# Altium schematic sheet LM2576-v2.SchDoc(LM2576-v2)
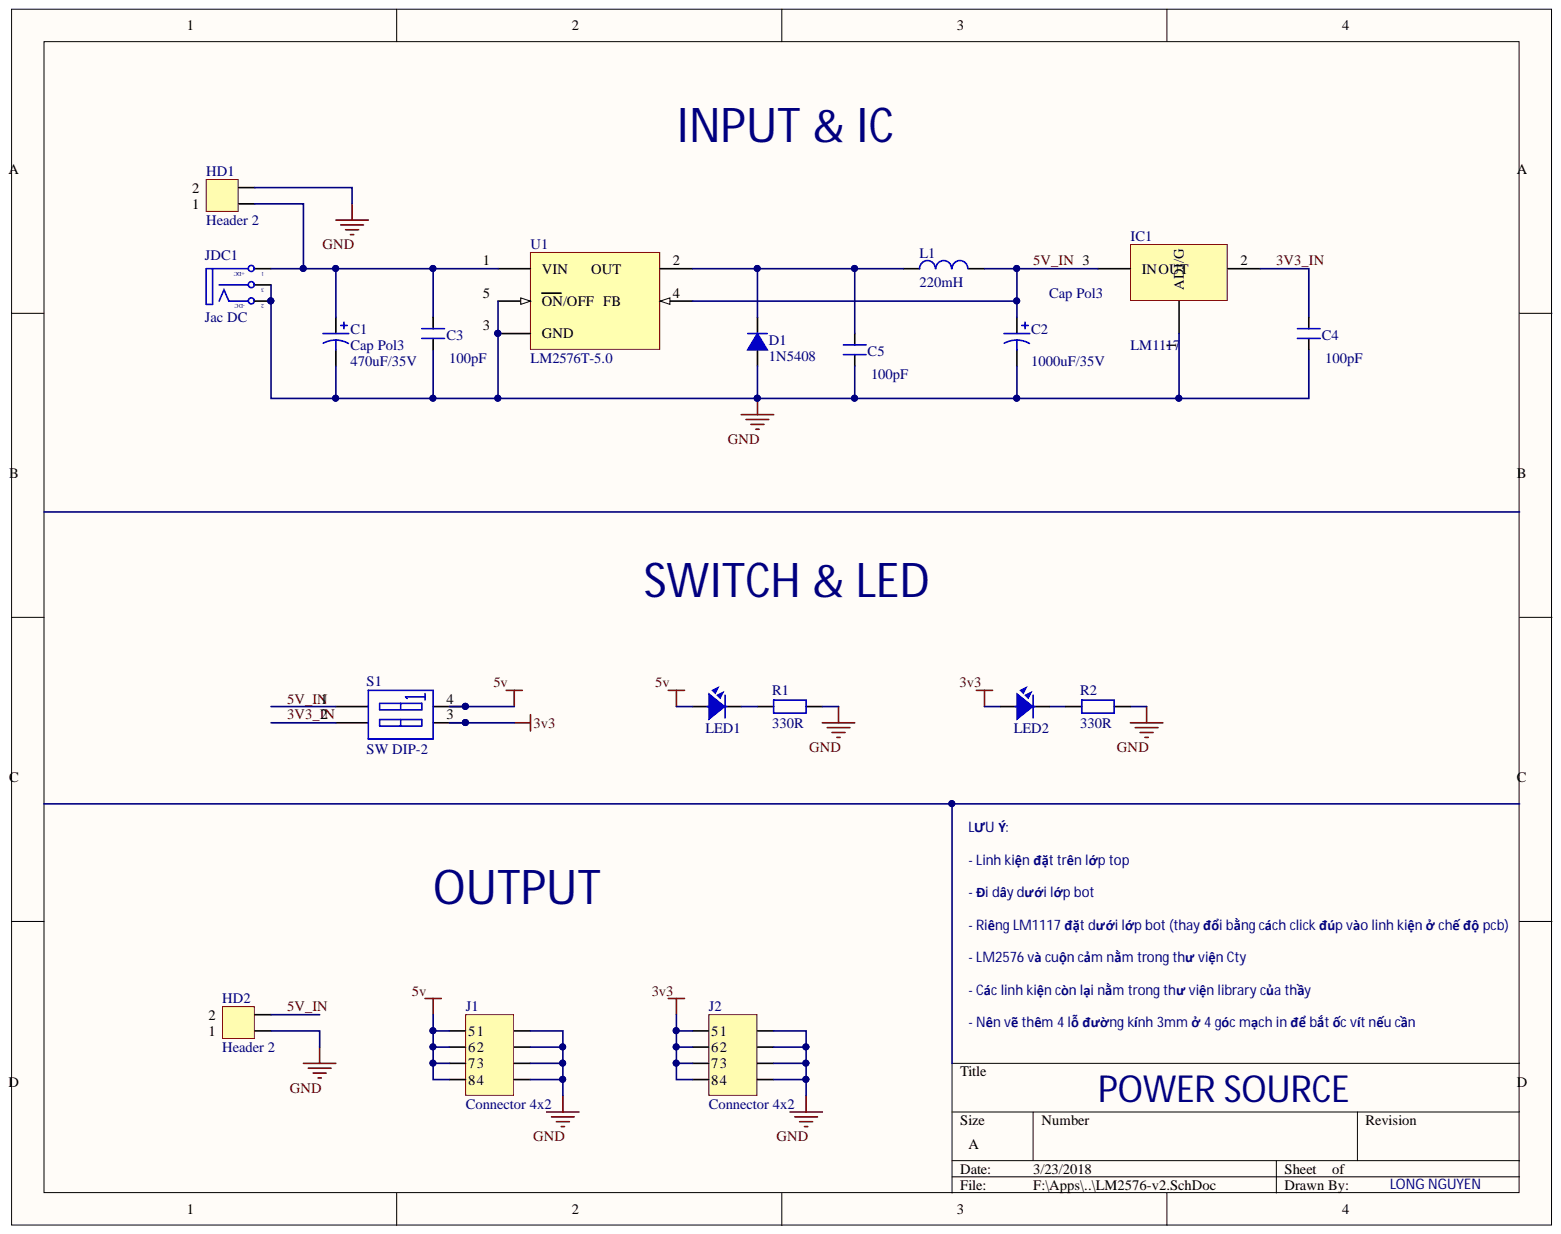
[diagram p0: LM2576-v2.SchDoc]

## Parts
C1  Cap Pol3  value 470uF/35V  [CP2/5]
C2  Cap Pol3  value 1000uF/35V  [CP2/5]
C3  100pF  [SM-CAP-3225]
C4  100pF  [SM-CAP-3225]
C5  100pF  [SM-CAP-3225]
D1  1N5408  [D5A]
HD1  Header 2  [HED2]
HD2  Header 2  [HED2]
IC1  LM1117  [SOT223-4N]
J1  Connector 4x2  [JP4x2]
J2  Connector 4x2  [JP4x2]
JDC1  Jac DC  [DC-IN]
L1  220mH  [L01]
LED1  LED  [SM-LED-0805-Red]
LED2  LED  [SM-LED-0805-Red]
R1  330R  [SM-RES-1206]
R2  330R  [SM-RES-1206]
S1  SW DIP-2  [DIPSWITCH2]
U1  LM2576T-5.0  [LM2576t]

## Nets
3V3: J2.1 J2.2 J2.3 J2.4 LED2.1 S1.3
5V: J1.1 J1.2 J1.3 J1.4 LED1.1 S1.4
GND: C1.2 C2.2 C3.1 C4.1 C5.1 D1.1 HD1.2 HD2.1 IC1.1 J1.5 J1.6 J1.7 J1.8 J2.5 J2.6 J2.7 J2.8 JDC1.2 JDC1.3 R1.2 R2.2 U1.3(GND) U1.5(ON/OFF)
3V3_IN: C4.2 IC1.2 S1.2
5V_IN: C2.1 HD2.2 IC1.3 L1.2 S1.1 U1.4
NetC1_1: C1.1 C3.2 HD1.1 JDC1.1 U1.1(VIN)
NetC5_2: C5.2 D1.2 L1.1 U1.2
NetLED1_2: LED1.2 R1.1
NetLED2_2: LED2.2 R2.1
N00006:
N00007:

## Library data of the parts
C1 C2 = Cap Pol3: Polarized Capacitor (Surface Mount); VALUE: C?; Component Kind: Standard; LatestRevisionDate: 17-Jul-2002; LatestRevisionNote: Re-released for DXP Platform.; Library Name: library.SchLib; PackageReference: C0805; Publisher: Altium Limited
C3-C5 = CN1: Cap np  1pF - 472pF; Component Kind: Standard; Library Name: library.SchLib; Value: 100pF
D1 = D5A: Diode 5A; Component Kind: Standard; Library Name: library.SchLib; Value: 1N5408
HD1 HD2 = Hed2: Header 2 (Green); Component Kind: Standard; Library Name: library.SchLib; Value: Header 2
IC1 = LM1117: Available in 1.8V, 2.5V, 2.85V, 3.3V, 5V, and  Adjustable Versions; Value: LM317; Component Kind: Standard; Library Name: library.SchLib
J1 J2 = JP4x2: Component Kind: Standard; Description: Connector 4x2; Library Name: library.SchLib; Value: Connector 4x2
JDC1 = DCIN: Jac DC input; Component Kind: Standard; Library Name: library.SchLib; Value: Jac DC
L1 = L1: Inductor; Value: 150 mH; Component Kind: Standard; Library Name: library.SchLib
LED1 LED2 = LED: LED Single colour Green and Red; Value: LED 3 ly Single Colour; Component Kind: Standard; Library Name: library.SchLib
R1 R2 = R1/4W: Resistor 1/4W; Value: R1/4W - 1K; Component Kind: Standard; Library Name: library.SchLib
S1 = SW DIP-2: DIP Switch, 2 Position, SPST; Component Kind: Standard; LatestRevisionDate: 17-Jul-2002; LatestRevisionNote: Re-released for DXP Platform.; Library Name: NBBLib-14-03-2012.IntLib; PackageReference: DIP-4; Published: 24-Mar-1999; Simulation: dpsw2; Publisher: Altium Limited
U1 = LM2576T-5.0: SIMPLE SWITCHER; 3A Step-Down Voltage Regulator; Manufacturer: National Semiconductor; PackageTopMarking: NSUZXYTT*LM2576T*-5.0 P+; PowerWise: N; Code_JEDEC: TO-220-AB; Component Kind: Standard; ComponentLink1Description: LM2576 Datasheet; ComponentLink1URL: http://www.national.com/ds/LM/LM2576.pdf; ComponentLink2Description: LM2576 Web Page; ComponentLink2URL: http://www.national.com/pf/LM/LM2576.html; ComponentLink3Description: T05A Package Page; ComponentLink3URL: http://www.national.com/packaging/folders/t05a.html; CompType: Switcher; DatasheetVersion: Aug-2004; DigiKeyPartNumber: LM2576T-5.0-ND; FirstPublished: 4-Dec-2008; Library Name: Cty1.SchLib; PackageDescription: TO-220, Molded, 5 Lead, Straight; PackageReference: T05A; PackageVersion: revJ, Jan-1992; PartNumber: LM2576T-5.0; PinCount: 5; RoHS: O; Publisher: Altium Limited
